# Altium schematic sheet CV-USB.SchDoc
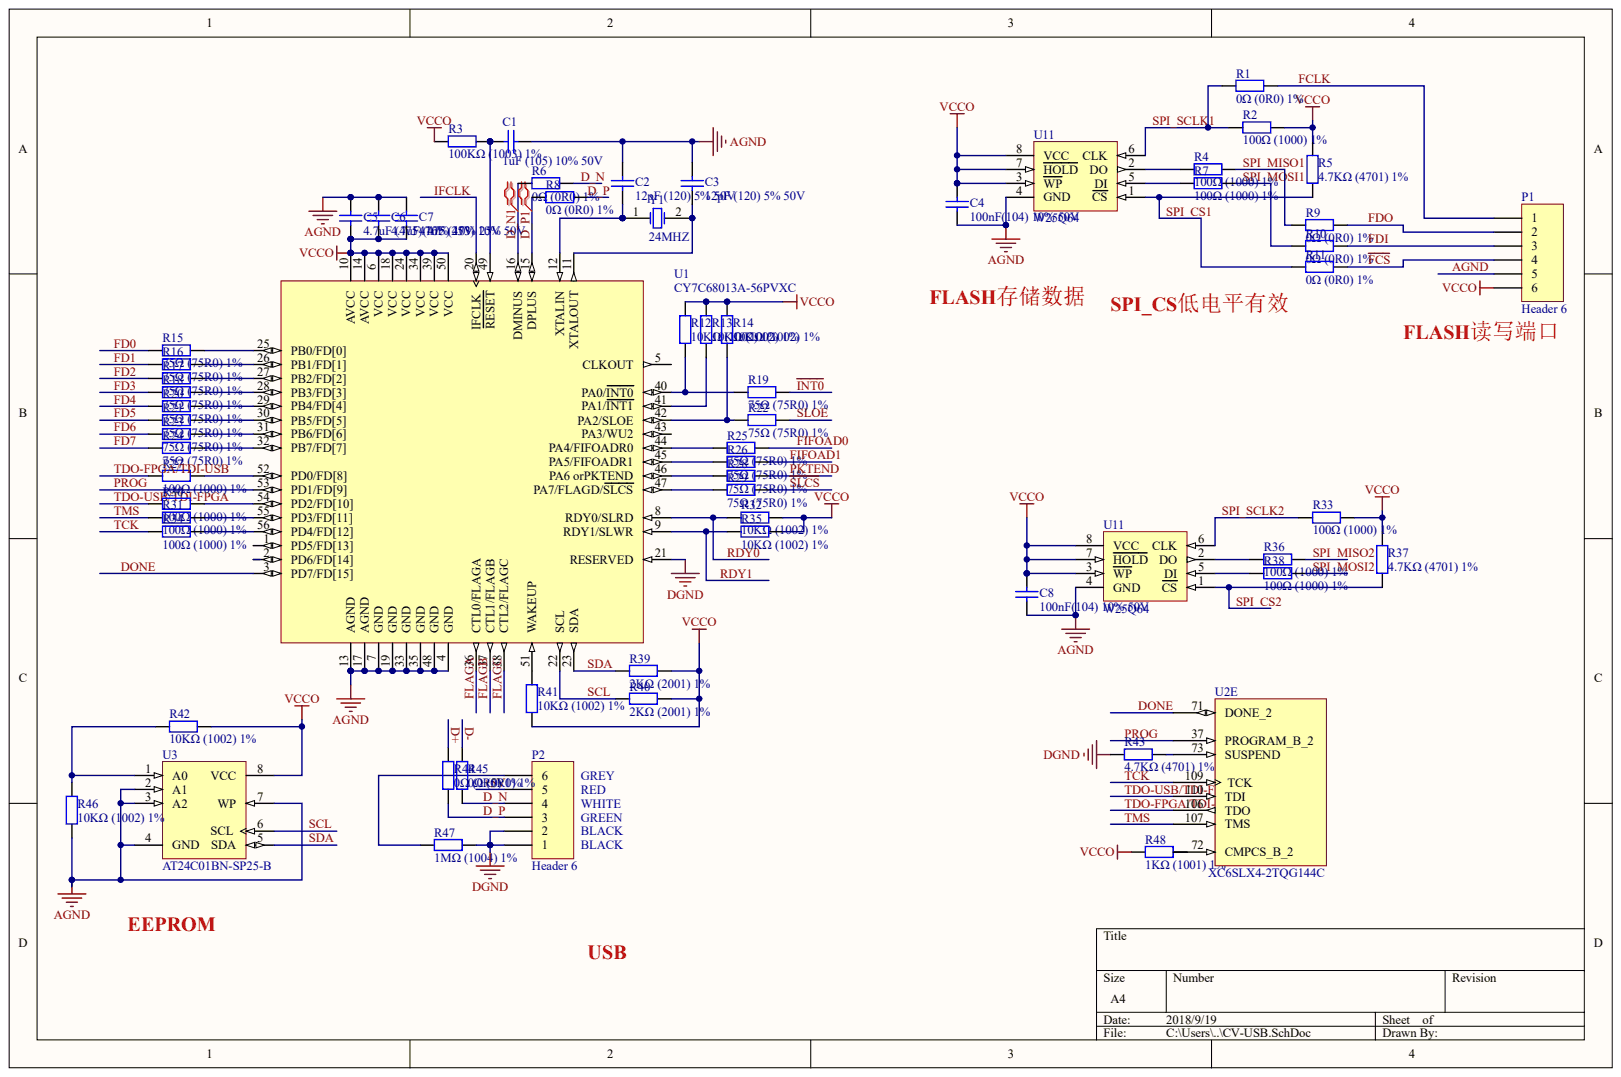
[diagram p0: CV-USB.SchDoc]

## Parts
C1  1uF (105) 10% 50V
C2  12pF (120) 5% 50V
C3  12pF (120) 5% 50V
C4  100nF(104) 10% 50V
C5  4.7uF (475) 10% 25V
C6  4.7uF (475) 10% 25V
C7  47nF (473) 10% 50V
C8  100nF(104) 10% 50V
P1  Header 6
P2  Header 6
R1  0Ω (0R0) ±1%
R2  100Ω (1000) ±1%
R3  100KΩ (1003) ±1%
R4  100Ω (1000) ±1%
R5  4.7KΩ (4701) ±1%
R6  0Ω (0R0) ±1%
R7  100Ω (1000) ±1%
R8  0Ω (0R0) ±1%
R9  0Ω (0R0) ±1%
R10  0Ω (0R0) ±1%
R11  0Ω (0R0) ±1%
R12  10KΩ (1002) ±1%
R13  10KΩ (1002) ±1%
R14  10KΩ (1002) ±1%
R15  75Ω (75R0) ±1%
R16  75Ω (75R0) ±1%
R17  75Ω (75R0) ±1%
R18  75Ω (75R0) ±1%
R19  75Ω (75R0) ±1%
R20  75Ω (75R0) ±1%
R21  75Ω (75R0) ±1%
R22  75Ω (75R0) ±1%
R23  75Ω (75R0) ±1%
R24  75Ω (75R0) ±1%
R25  75Ω (75R0) ±1%
R26  75Ω (75R0) ±1%
R27  100Ω (1000) ±1%
R28  75Ω (75R0) ±1%
R29  75Ω (75R0) ±1%
R30  100Ω (1000) ±1%
R31  100Ω (1000) ±1%
R32  10KΩ (1002) ±1%
R33  100Ω (1000) ±1%
R34  100Ω (1000) ±1%
R35  10KΩ (1002) ±1%
R36  100Ω (1000) ±1%
R37  4.7KΩ (4701) ±1%
R38  100Ω (1000) ±1%
R39  2KΩ (2001) ±1%
R40  2KΩ (2001) ±1%
R41  10KΩ (1002) ±1%
R42  10KΩ (1002) ±1%
R43  4.7KΩ (4701) ±1%
R44  0Ω (0R0) ±1%  (value not drawn)
R45  0Ω (0R0) ±1%  (value not drawn)
R46  10KΩ (1002) ±1%
R47  1MΩ (1004) ±1%
R48  1KΩ (1001) ±1%
U1  CY7C68013A-56PVXC
U2  XC6SLX4-2TQG144C  (part E)
U3  AT24C01BN-SP25-B
U11  W25Q64
U11  W25Q64
Y1  24MHZ

## Nets
DGND: P2.1 P2.2 R43.1 R47.2 U1.21(RESERVED)
VCCO: C4.2 C5.1 C6.1 C7.1 C8.2 P1.6 R2.2 R3.1 R5.2 R12.2 R13.2 R14.2 R32.1 R33.2 R35.1 R37.2 R39.2 R40.2 R41.1 R42.2 R48.1 U1.6(VCC) U1.10(AVCC) U1.14(AVCC) U1.18(VCC) U1.24(VCC) U1.34(VCC) U1.39(VCC) U1.50(VCC) U3.8(VCC) U11.3 U11.7 U11.8
+5V: P2.5
~{FCS}: P1.4 R11.2
~{INT0}: R19.2
~{SLCS}: R29.2
AGND: C1.2 C2.2 C3.2 C4.1 C5.2 C6.2 C7.2 C8.1 P1.5 R46.1 U1.4(GND) U1.7(GND) U1.13(AGND) U1.17(AGND) U1.19(GND) U1.33(GND) U1.35(GND) U1.48(GND) U3.2(A1) U3.3(A2) U3.4(GND) U3.7(WP) U11.4
D+: R44.1
D-: R45.1
D_N: P2.4 R6.2 R45.2
D_N1: R6.1 U1.16(DMINUS)
D_P: P2.3 R8.2 R44.2
D_P1: R8.1 U1.15(DPLUS)
DONE: U1.3(PD7/FD[15]) U2.71(DONE_2)
FCLK: P1.1 R1.2
FD0: R15.1
FD1: R16.1
FD2: R17.1
FD3: R18.1
FD4: R20.1
FD5: R21.1
FD6: R23.1
FD7: R24.1
FDI: P1.3 R10.2
FDO: P1.2 R9.2
FIFOAD0: R25.2
FIFOAD1: R26.2
FLAGA: U1.36(CTL0/FLAGA)
FLAGB: U1.37(CTL1/FLAGB)
FLAGC: U1.38(CTL2/FLAGC)
IFCLK: U1.20(IFCLK)
PKTEND: R28.2
PROG: U1.53(PD1/FD[9]) U2.37(PROGRAM_B_2)
RDY0: R32.2 U1.8(RDY0/SLRD)
RDY1: R35.2 U1.9(RDY1/SLWR)
SCL: R40.1 U1.22(SCL) U3.6(SCL)
SDA: R39.1 U1.23(SDA) U3.5(SDA)
SLOE: R22.2
SPI_CS1: R5.1 R11.1 U11.1
SPI_CS2: R37.1 U11.1
SPI_MISO1: R4.2 R9.1
SPI_MISO2: R36.2
SPI_MOSI1: R7.2 R10.1
SPI_MOSI2: R38.2
SPI_SCLK1: R1.1 R2.1 U11.6
SPI_SCLK2: R33.1 U11.6
TCK: R34.1 U2.109(TCK)
TDO-FPGA/TDI-USB: R27.1 U2.106(TDO)
TDO-USB/TDI-FPGA: R30.1 U2.110(TDI)
TMS: R31.1 U2.107(TMS)
NetC1_1: C1.1 R3.2 U1.49(RESET)
NetC2_1: C2.1 U1.12(XTALIN) Y1.1
NetC3_1: C3.1 U1.11(XTALOUT) Y1.2
NetP2_6: P2.6 R47.1
NetR4_1: R4.1 U11.2
NetR7_1: R7.1 U11.5
NetR12_1: R12.1 R19.1 U1.40(PA0/INT0)
NetR13_1: R13.1 U1.41(PA1/INT1)
NetR14_1: R14.1 R22.1 U1.42(PA2/SLOE)
NetR15_2: R15.2 U1.25(PB0/FD[0])
NetR16_2: R16.2 U1.26(PB1/FD[1])
NetR17_2: R17.2 U1.27(PB2/FD[2])
NetR18_2: R18.2 U1.28(PB3/FD[3])
NetR20_2: R20.2 U1.29(PB4/FD[4])
NetR21_2: R21.2 U1.30(PB5/FD[5])
NetR23_2: R23.2 U1.31(PB6/FD[6])
NetR24_2: R24.2 U1.32(PB7/FD[7])
NetR25_1: R25.1 U1.44(PA4/FIFOADR0)
NetR26_1: R26.1 U1.45(PA5/FIFOADR1)
NetR27_2: R27.2 U1.52(PD0/FD[8])
NetR28_1: R28.1 U1.46(PA6_orPKTEND)
NetR29_1: R29.1 U1.47(PA7/FLAGD/SLCS)
NetR30_2: R30.2 U1.54(PD2/FD[10])
NetR31_2: R31.2 U1.55(PD3/FD[11])
NetR34_2: R34.2 U1.56(PD4/FD[12])
NetR36_1: R36.1 U11.2
NetR38_1: R38.1 U11.5
NetR41_2: R41.2 U1.51(WAKEUP)
NetR42_1: R42.1 R46.2 U3.1(A0)
NetR43_2: R43.2 U2.73(SUSPEND)
NetR48_2: R48.2 U2.72(CMPCS_B_2)
BLACK:
GREEN:
GREY:
RED:
WHITE:

Not connected: U1 1(PD5/FD[13]) 2(PD6/FD[14]) 5(CLKOUT) 43(PA3/WU2)

## Library data of the parts
C1-C8 P1 P2 R1-R48 U1-U3 U11 U11 Y1 = (no symbol name)
  C1: ComponentLink1Description: 供应商链接; ComponentLink1URL: http://www.szlcsc.com/product/details_29074.html; Package: 0805; Supplier: LC; SuppliersPartNumber: C28323
  C2 C3: ComponentLink1Description: 供应商链接; ComponentLink1URL: http://www.szlcsc.com/product/details_2144.html; Package: 0805; Supplier: LC; SuppliersPartNumber: C1792
  C4 C8: ComponentLink1Description: 供应商链接; ComponentLink1URL: http://www.szlcsc.com/product/details_50686.html; Package: 0805; Supplier: LC; SuppliersPartNumber: C49678
  C5 C6: ComponentLink1Description: 供应商链接; ComponentLink1URL: http://www.szlcsc.com/product/details_2131.html; Package: 0805; Supplier: LC; SuppliersPartNumber: C1779
  C7: ComponentLink1Description: 供应商链接; ComponentLink1URL: http://www.szlcsc.com/product/details_54150.html; Package: 0805; Supplier: LC; SuppliersPartNumber: C53134
  P1 P2: LatestRevisionDate: 17-Jul-2002; LatestRevisionNote: Re-released for DXP Platform.
  R1 R6 R8-R11 R44 R45: ComponentLink1Description: 供应商链接; ComponentLink1URL: http://www.szlcsc.com/product/details_18165.html; Package: 0805; Supplier: LC; SuppliersPartNumber: C17477
  R2 R4 R7 R27 R30 R31 R33 R34 R36 R38: ComponentLink1Description: 供应商链接; ComponentLink1URL: http://www.szlcsc.com/product/details_18096.html; Package: 0805; Supplier: LC; SuppliersPartNumber: C17408
  R3: ComponentLink1Description: 供应商链接; ComponentLink1URL: http://www.szlcsc.com/product/details_18095.html; Package: 0805; Supplier: LC; SuppliersPartNumber: C17407
  R5 R37 R43: ComponentLink1Description: 供应商链接; ComponentLink1URL: http://www.szlcsc.com/product/details_18361.html; Package: 0805; Supplier: LC; SuppliersPartNumber: C17673
  R12-R14 R32 R35 R41 R42 R46: ComponentLink1Description: 供应商链接; ComponentLink1URL: http://www.szlcsc.com/product/details_18102.html; Package: 0805; Supplier: LC; SuppliersPartNumber: C17414
  R15-R26 R28 R29: ComponentLink1Description: 供应商链接; ComponentLink1URL: http://www.szlcsc.com/product/details_21350.html; Package: 0805; Supplier: LC; SuppliersPartNumber: C20638
  R39 R40: ComponentLink1Description: 供应商链接; ComponentLink1URL: http://www.szlcsc.com/product/details_18292.html; Package: 0805; Supplier: LC; SuppliersPartNumber: C17604
  R47: ComponentLink1Description: 供应商链接; ComponentLink1URL: http://www.szlcsc.com/product/details_18202.html; Package: 0805; Supplier: LC; SuppliersPartNumber: C17514
  R48: ComponentLink1Description: 供应商链接; ComponentLink1URL: http://www.szlcsc.com/product/details_18201.html; Package: 0805; Supplier: LC; SuppliersPartNumber: C17513
  U1: Published: 16-Dec-2005; LatestRevisionDate: 20-Nov-2009; LatestRevisionNote: IPC-7351 Footprint Added.; DatasheetVersion: rev.J, Sep-2005; PackageVersion: Feb-2005; PackageReference: O56; PackageDescription: 56-Pin Small Outline Package 0.635 mm Pitch; Code_JEDEC: MO-118-AB; Code_IPC: SOP635P1035-56; ComponentLink1Description: Manufacturer Link; ComponentLink1URL: http://www.cypress.com/portal/server.pt; ComponentLink2Description: Datasheet; ComponentLink2URL: http://www.cypress.com/portal/server.pt/gateway/PTARGS_0_2_1524_209_259_43/http%3B/sjapp20.mis.cypress.com%3B7001/publishedcontent/publish/design_resources/datasheets/contents/cy7c68013a_5.pdf
  U2: Published: 1-Jul-2010; DatasheetVersion: ver1.6, Nov-2010; PackageVersion: ver1.2, Jun-2004; PackageReference: TQG144; PackageDescription: 144-Pin Thin Quad Flat Pack (TQG144) - Pb-Free; Supplier 1: Digi-Key; Supplier Part Number 1: XC6SLX4-2TQG144C-ND; Code_JEDEC: MS-026-BFB; ComponentLink1Description: Manufacturer Link; ComponentLink1URL: http://www.xilinx.com; ComponentLink2Description: Spartan-6 Datasheet; ComponentLink2URL: http://www.xilinx.com/support/documentation/data_sheets/ds160.pdf; ComponentLink3Description: Spartan-6 Documents; ComponentLink3URL: http://www.xilinx.com/support/documentation/spartan-6.htm; ComponentLink4Description: Xilinx.com Home; ComponentLink4URL: http://www.xilinx.com
  U3: Published: 20-Sep-2010; DatasheetVersion: 8517C,  Jan-2009; PackageVersion: revC, Mar-2005; PackageReference: 8S1; PackageDescription: 8-Lead (0.150" Wide Body), Plastic Gull Wing Small Outline (JEDEC SOIC); Code_JEDEC: MS-012-AA; Code_IPC: SOIC127P600-8; ComponentLink1Description: Manufacturer Link; ComponentLink1URL: http://www.atmel.com/products/serial/default.asp; ComponentLink2Description: Datasheet; ComponentLink2URL: http://www.atmel.com/dyn/resources/prod_documents/doc8517.pdf; ComponentLink3Description: Atmel.com Home; ComponentLink3URL: http://www.atmel.com
  Y1: Published: 8-Jun-2000; LatestRevisionDate: 17-Jul-2002; LatestRevisionNote: Re-released for DXP Platform.; PackageReference: R38
  P1 P2 U1-U3 Y1: Publisher: Altium Limited
